# Altium schematic sheet SDcard.SchDoc
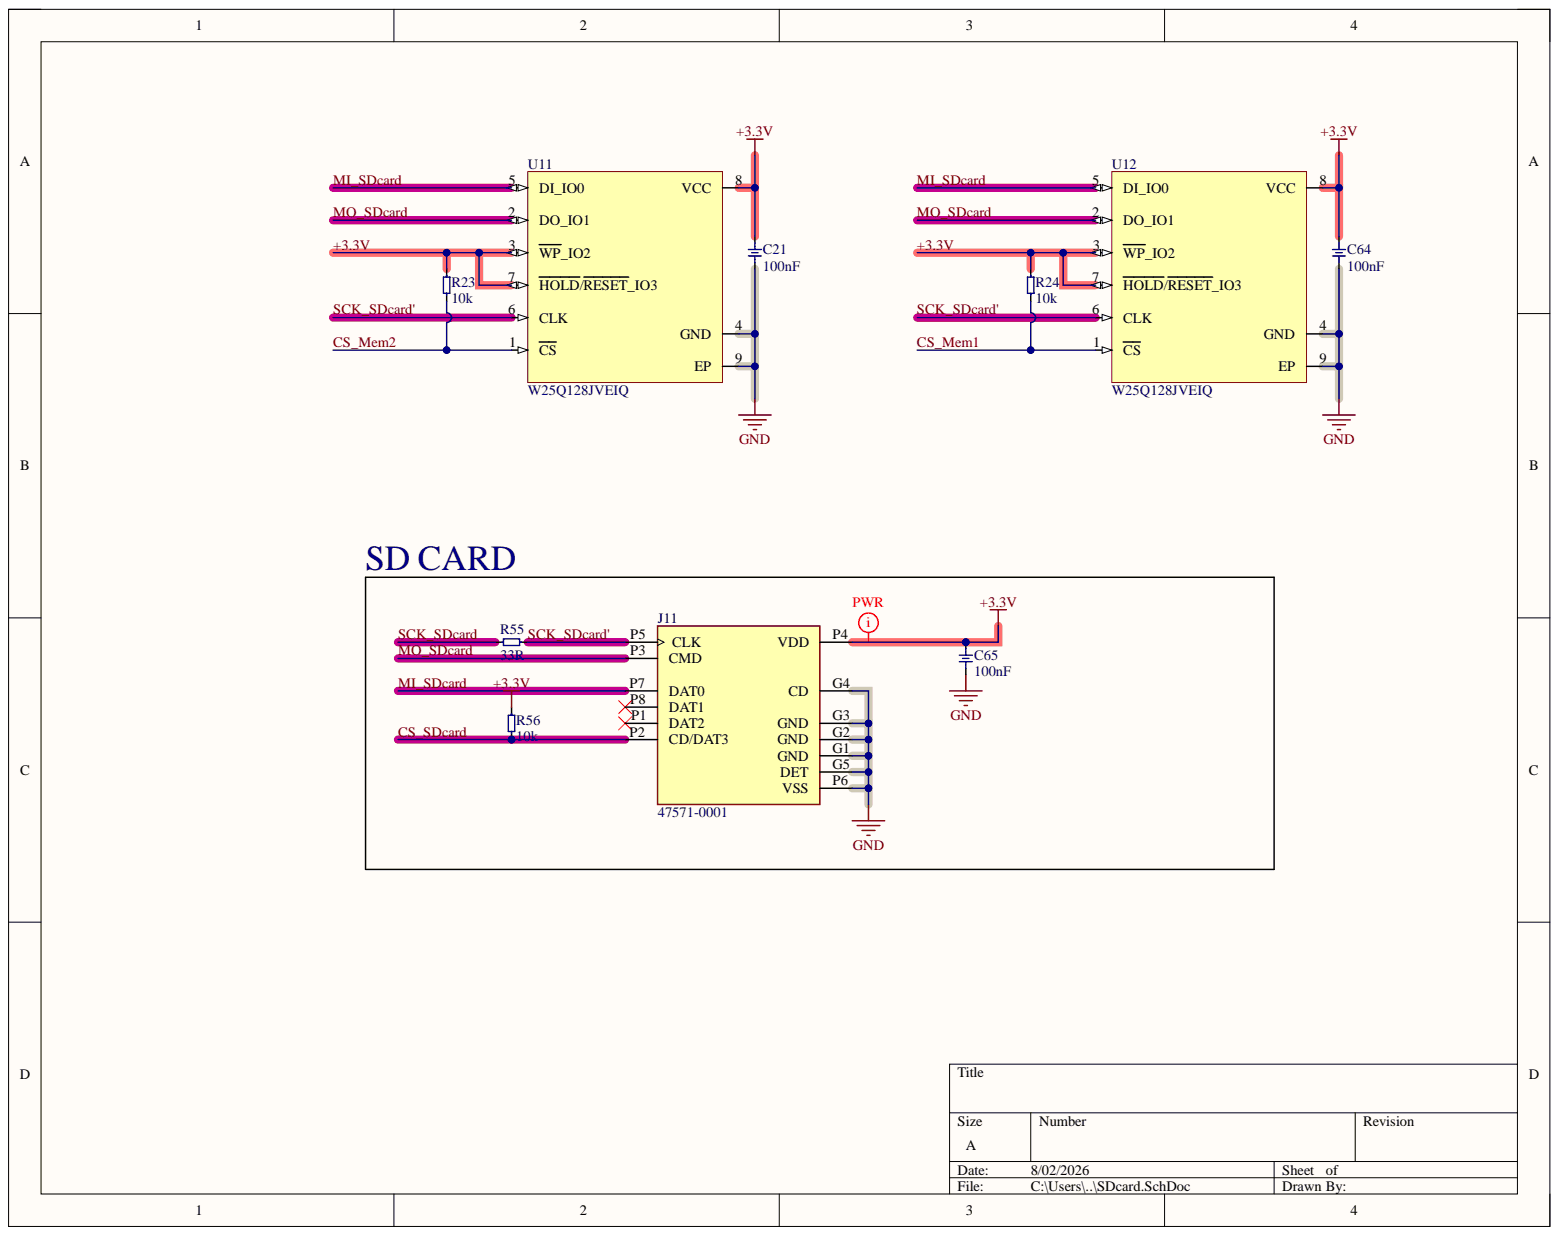
[diagram p0: SDcard.SchDoc]

## Parts
C21  100nF  [CAP_0603]
C64  100nF  [CAP_0603]
C65  100nF  [CAP_0603]
J11  47571-0001  [MOLEX_47571-0001_0]
R23  10k  [RES_0603]
R24  10k  [RES_0603]
R55  33R  [RES_0603]
R56  10k  [RES_0603]
U11  W25Q128JVEIQ  [WSON-8-8X6]
U12  W25Q128JVEIQ  [WSON-8-8X6]

## Nets
GND: C21.1 C64.1 C65.1 J11.G1(GND) J11.G2(GND) J11.G3(GND) J11.G4(CD) J11.G5(DET) J11.P6(VSS) U11.4(GND) U11.9(EP) U12.4(GND) U12.9(EP)
+3.3V: C21.2 C64.2 C65.2 J11.P4(VDD) R23.2 R24.2 R56.2 U11.3(WP_IO2) U11.7(HOLD/RESET_IO3) U11.8(VCC) U12.3(WP_IO2) U12.7(HOLD/RESET_IO3) U12.8(VCC)
CS_Mem1: R24.1 U12.1(CS)
CS_Mem2: R23.1 U11.1(CS)
CS_SDcard: J11.P2(CD/DAT3) R56.1
MI_SDcard: J11.P7(DAT0) U11.5(DI_IO0) U12.5(DI_IO0)
MO_SDcard: J11.P3(CMD) U11.2(DO_IO1) U12.2(DO_IO1)
SCK_SDcard: R55.1
SCK_SDcard': J11.P5(CLK) R55.2 U11.6(CLK) U12.6(CLK)

Not connected: J11 P1(DAT2) P8(DAT1)

## Library data of the parts
C21 C64 C65 = CAP_0603: Component Kind: Standard; Library Name: RQSchlib.SchLib
J11 = 47571-0001: 1.1mm Pitch microSD Card HeaderDetect Switch, Height 2.3mm | Molex Incorporated 47571-0001; Check_prices: https://www.snapeda.com/parts/47571-0001/Molex/view-part/?ref=eda; Component Kind: Standard; MF: Molex; MP: 47571-0001; Package: None; SnapEDA_Link: https://www.snapeda.com/parts/47571-0001/Molex/view-part/?ref=snap; Library Name: RQSchlib.SchLib
R23 R24 R55 R56 = RES_0603: Component Kind: Standard; Library Name: RQSchlib.SchLib
U11 U12 = W25Q128JVEIQ: 3V 128M-BIT SERIAL FLASH MEMORY WITH DUAL/QUAD SPI & QPI & DTR WSON-8; Manufacturer: Winbond; Component Kind: Standard; Manufacturer Part Number: W25Q128JVEIQ; Library Name: RQSchlib.SchLib
